# Altium schematic sheet Drive_D 1V3.SchDoc("Drive_D 1V3")
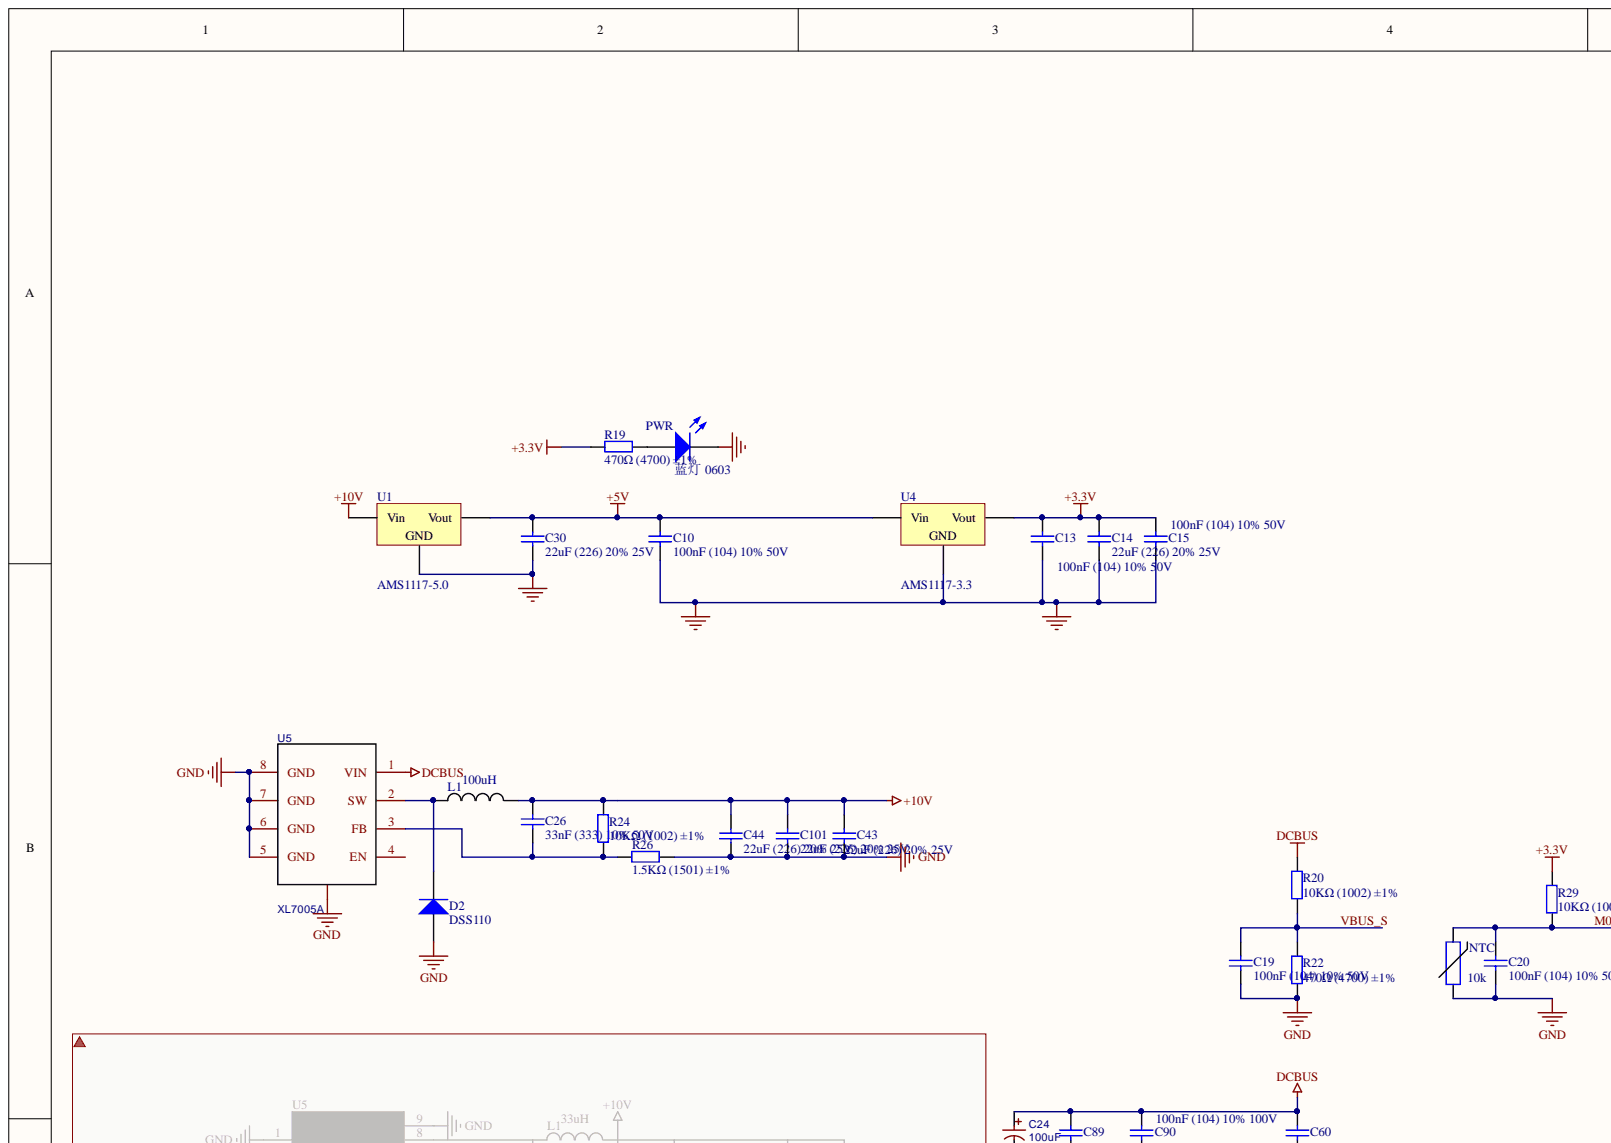
[diagram p0: Drive_D 1V3.SchDoc, top left]
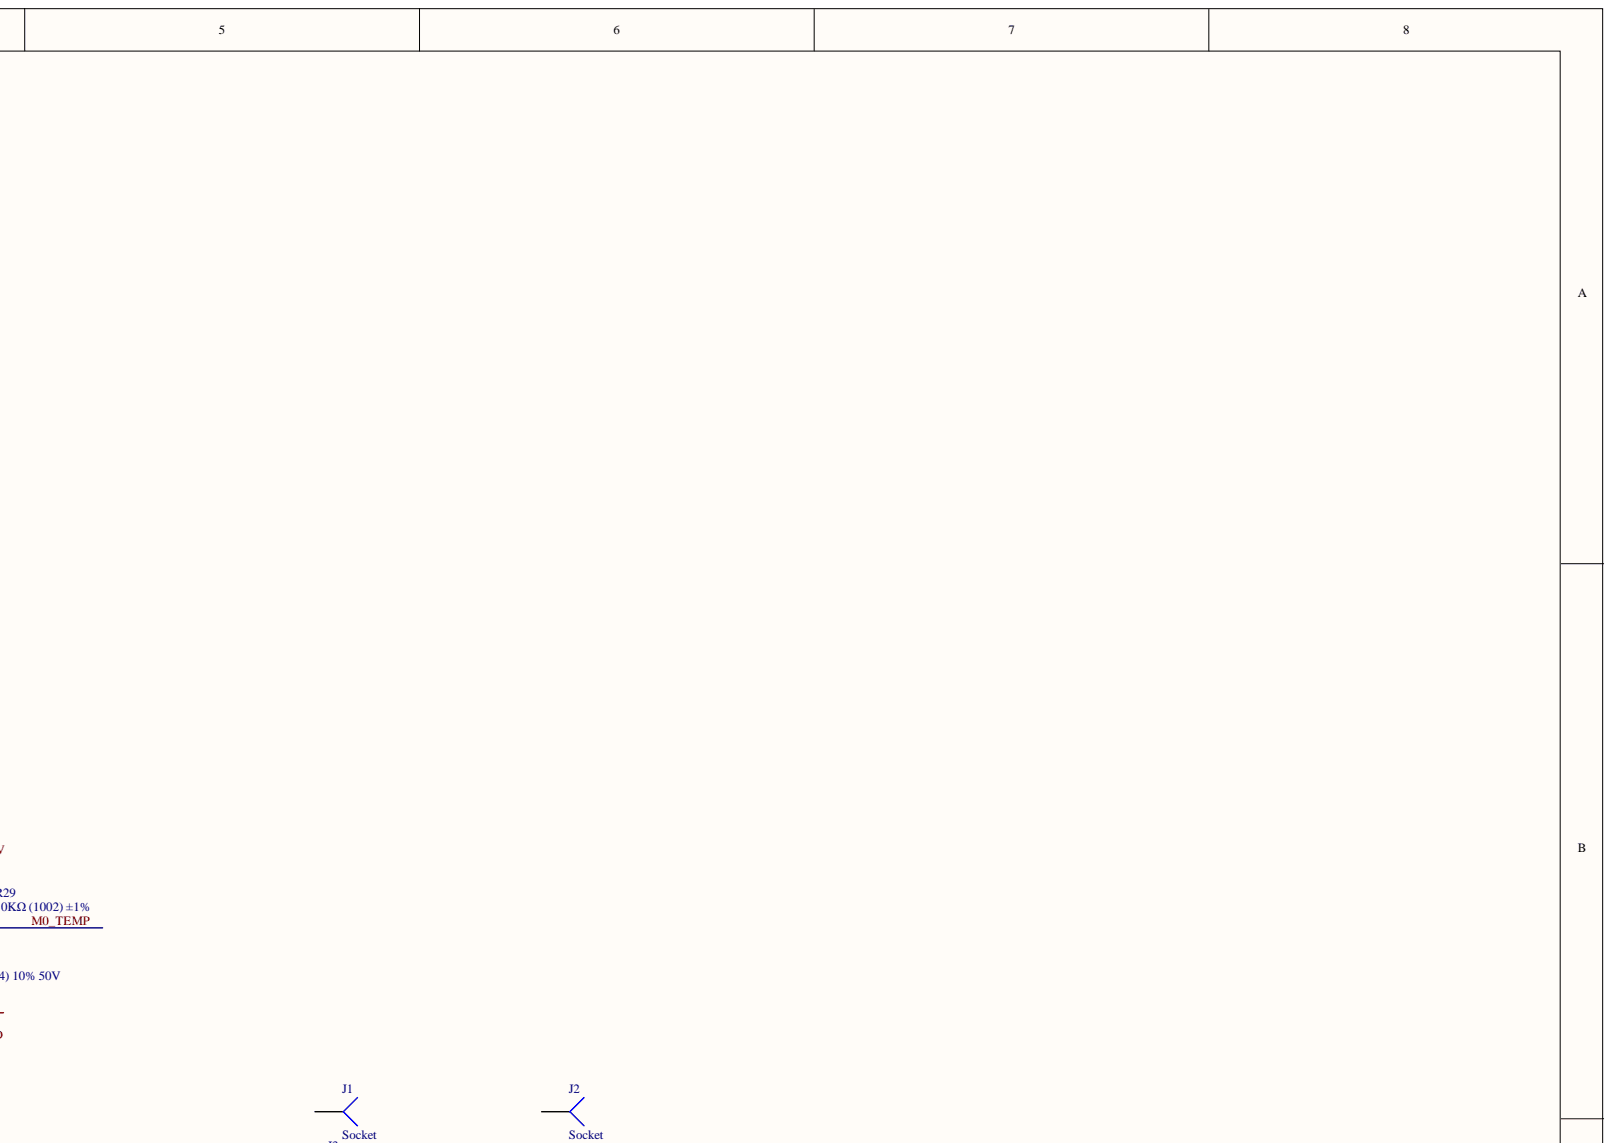
[diagram p1: Drive_D 1V3.SchDoc, top right]
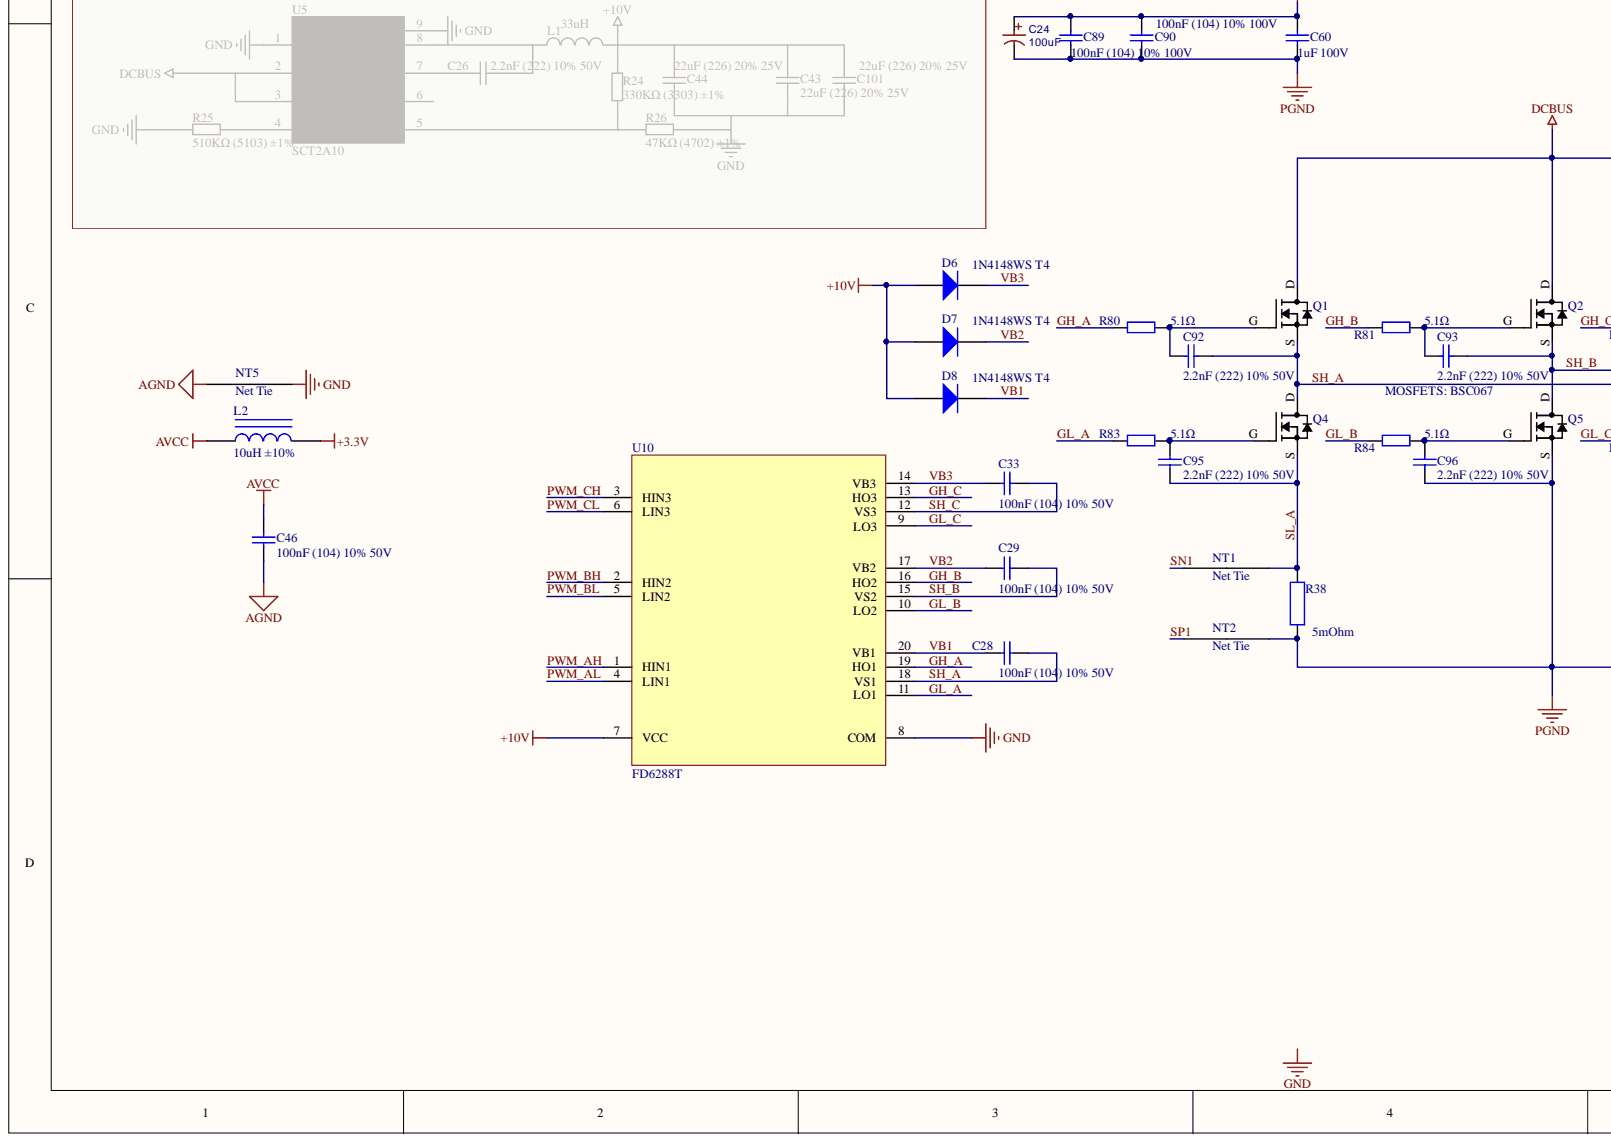
[diagram p2: Drive_D 1V3.SchDoc, bottom left]
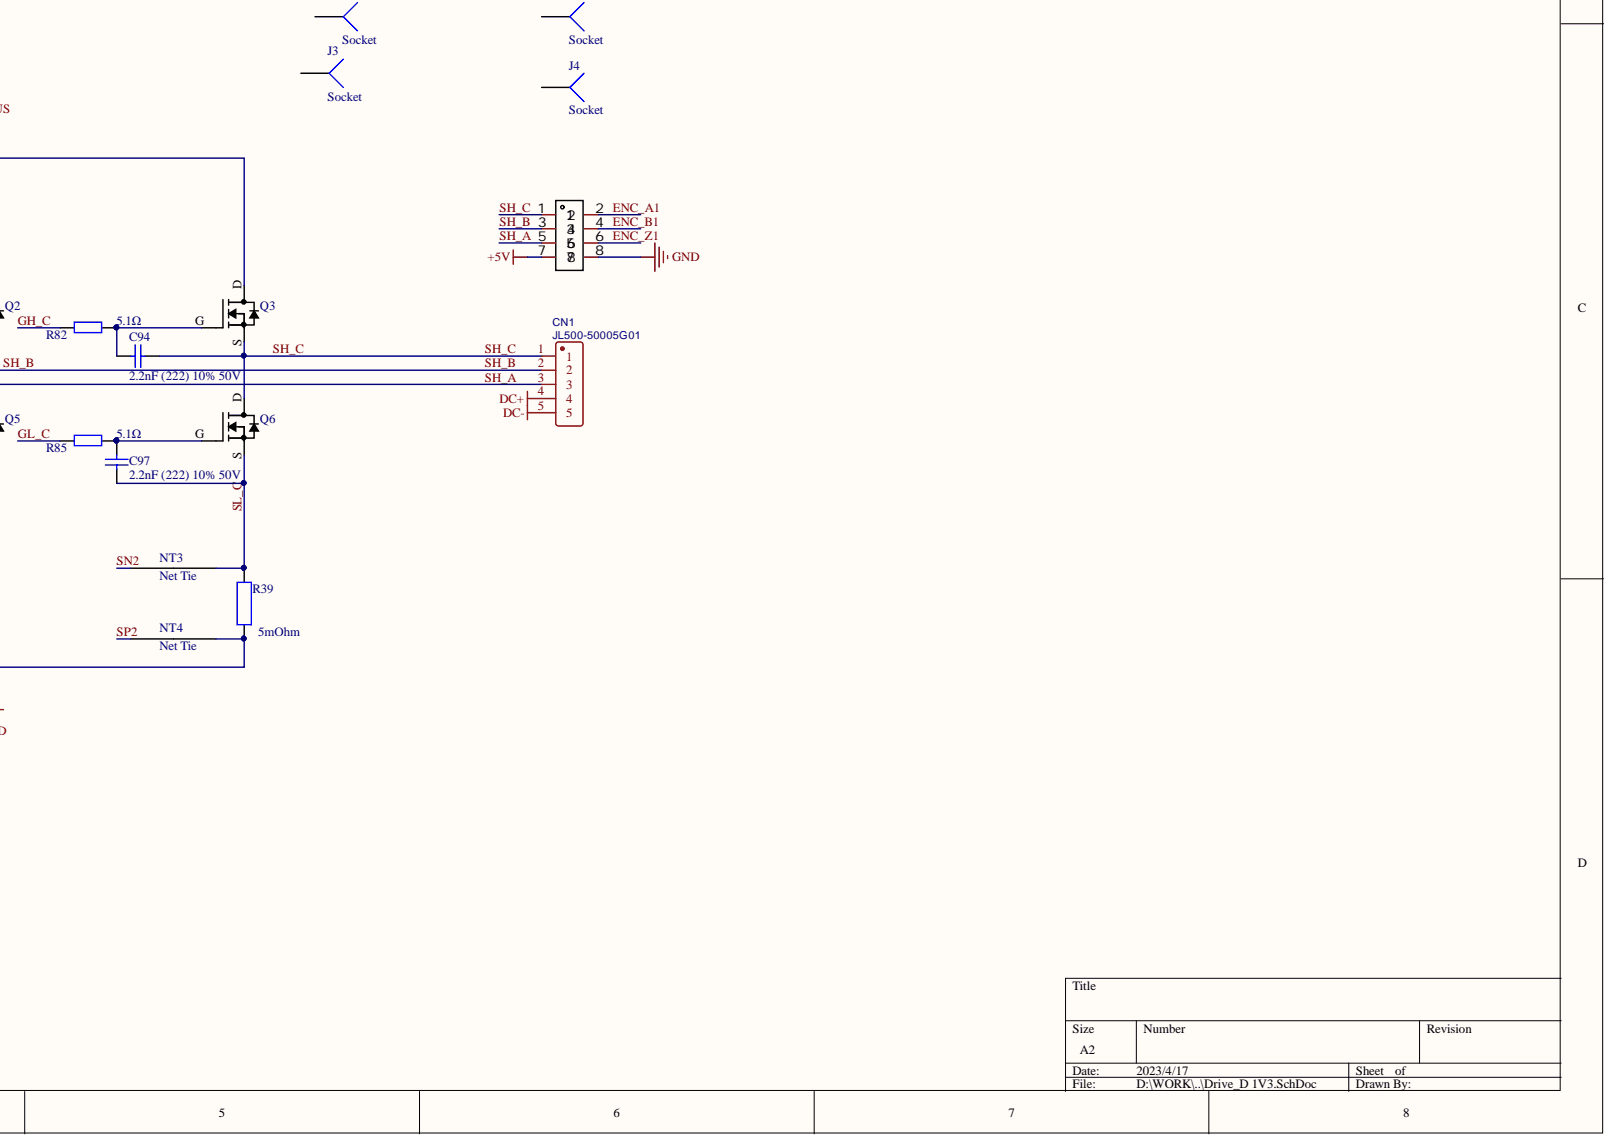
[diagram p3: Drive_D 1V3.SchDoc, bottom right]

## Parts
C10  100nF (104) 10% 50V  [0603_C]
C13  100nF (104) 10% 50V  [0603_C]
C14  22uF (226) 20% 25V  [0805_C]
C15  100nF (104) 10% 50V  [0603_C]
C19  100nF (104) 10% 50V  [0603_C]
C20  100nF (104) 10% 50V  [0603_C]
C24  100uF  [CE-5.0*10*12.5 - BK]
C26  33nF (333) 10% 50V  [0603_C]
C28  100nF (104) 10% 50V  [0603_C]
C29  100nF (104) 10% 50V  [0603_C]
C30  22uF (226) 20% 25V  [0805_C]
C33  100nF (104) 10% 50V  [0603_C]
C43  22uF (226) 20% 25V  [0805_C]
C44  22uF (226) 20% 25V  [0805_C]
C46  100nF (104) 10% 50V  [0603_C]
C60  1uF 100V  [1206_C]
C89  100nF (104) 10% 100V  [0603_C]
C90  100nF (104) 10% 100V  [0603_C]
C92  2.2nF (222) 10% 50V  [0603_C]
C93  2.2nF (222) 10% 50V  [0603_C]
C94  2.2nF (222) 10% 50V  [0603_C]
C95  2.2nF (222) 10% 50V  [0603_C]
C96  2.2nF (222) 10% 50V  [0603_C]
C97  2.2nF (222) 10% 50V  [0603_C]
C101  22uF (226) 20% 25V  [0805_C]
CN1  JL500-50005G01  [CONN-TH_JL500-50005G01]
CN4  X3025WR-2x04-46SN  [CONN-TH_X3025WR-2-04-46SN]  (value not drawn)
D2  DSS110  [SOD-123]
D6  1N4148WS T4  [SOD-323]
D7  1N4148WS T4  [SOD-323]
D8  1N4148WS T4  [SOD-323]
J1  Socket  [PCBComponent_1]
J2  Socket  [PCBComponent_1]
J3  Socket  [PCBComponent_1]
J4  Socket  [PCBComponent_1]
L1  value 100uH  [NR4020]  (hidden comment: 22uH)
L2  10uH ±10%  [0805_L]
NT1  0.25mm  [NetTie_0.25mm]  (value not drawn)
NT2  0.25mm  [NetTie_0.25mm]  (value not drawn)
NT3  0.25mm  [NetTie_0.25mm]  (value not drawn)
NT4  0.25mm  [NetTie_0.25mm]  (value not drawn)
NT5  0.25mm  [NetTie_0.25mm]  (value not drawn)
NTC  10k  [0603_R]
PWR  蓝灯 0603  Package: 0603  [0603_LED_S1]
Q1  (no value)  [PG-TDSON-8]
Q2  (no value)  [PG-TDSON-8]
Q3  (no value)  [PG-TDSON-8]
Q4  (no value)  [PG-TDSON-8]
Q5  (no value)  [PG-TDSON-8]
Q6  (no value)  [PG-TDSON-8]
R19  470Ω (4700) ±1%  [0603_R]
R20  10KΩ (1002) ±1%  [0603_R]
R22  470Ω (4700) ±1%  [0603_R]
R24  10KΩ (1002) ±1%  [0603_R]
R26  1.5KΩ (1501) ±1%  [0603_R]
R29  10KΩ (1002) ±1%  [0603_R]
R38  value 5mOhm  [R2512_M]  (hidden comment: 500uOhm)
R39  value 5mOhm  [R2512_M]  (hidden comment: 500uOhm)
R80  5.1Ω  [0603_R]
R81  5.1Ω  [0603_R]
R82  5.1Ω  [0603_R]
R83  5.1Ω  [0603_R]
R84  5.1Ω  [0603_R]
R85  5.1Ω  [0603_R]
U1  AMS1117-5.0  [SOT89_M]
U4  AMS1117-3.3  [SOT89_M]
U5  XL7005A  [LM5164]
U10  FD6288T  [TSSOP20_M]

## Nets
+3.3V: C13.2 C14.2 C15.2 L2.2 R19.1 R29.2 U4.2
+5V: C10.2 C30.2 CN4.7 U1.2 U4.3
+10V: C26.2 C43.2 C44.2 C101.2 D6.1 D7.1 D8.1 L1.2 R24.1 U1.3 U10.7(VCC)
AGND: C46.1 NT5.1
AVCC: C46.2 L2.1
DC+: CN1.4
DC-: CN1.5
DCBUS: C24.1 C60.2 C89.2 C90.2 Q1.D Q2.D Q3.D R20.2 U5.1(VIN)
GND: C10.1 C13.1 C14.1 C15.1 C19.1 C20.1 C30.1 C43.1 C44.1 C101.1 CN4.8 D2.1 NT5.2 NTC.1 PWR.K R22.1 R26.2 U1.1 U4.1 U5.5(GND) U5.6(GND) U5.7(GND) U5.8(GND) U5.9 U10.8(COM)
PGND: C24.2 C60.1 C89.1 C90.1 C96.1 NT2.2 NT4.2 Q5.S R38.1 R39.1
ENC_A1: CN4.2
ENC_B1: CN4.4
ENC_Z1: CN4.6
GH_A: R80.1 U10.19(HO1)
GH_B: R81.1 U10.16(HO2)
GH_C: R82.1 U10.13(HO3)
GL_A: R83.1 U10.11(LO1)
GL_B: R84.1 U10.10(LO2)
GL_C: R85.1 U10.9(LO3)
M0_TEMP: C20.2 NTC.2 R29.1
PWM_AH: U10.1(HIN1)
PWM_AL: U10.4(LIN1)
PWM_BH: U10.2(HIN2)
PWM_BL: U10.5(LIN2)
PWM_CH: U10.3(HIN3)
PWM_CL: U10.6(LIN3)
SH_A: C28.1 C92.1 CN1.3 CN4.5 Q1.S Q4.D U10.18(VS1)
SH_B: C29.1 C93.1 CN1.2 CN4.3 Q2.S Q5.D U10.15(VS2)
SH_C: C33.1 C94.1 CN1.1 CN4.1 Q3.S Q6.D U10.12(VS3)
SL_A: C95.1 NT1.2 Q4.S R38.2
SL_C: C97.1 NT3.2 Q6.S R39.2
SN1: NT1.1
SN2: NT3.1
SP1: NT2.1
SP2: NT4.1
VB1: C28.2 D8.2 U10.20(VB1)
VB2: C29.2 D7.2 U10.17(VB2)
VB3: C33.2 D6.2 U10.14(VB3)
VBUS_S: C19.2 R20.1 R22.2
NetC26_1: C26.1 R24.2 R26.1 U5.3(FB)
NetC92_2: C92.2 Q1.G R80.2
NetC93_2: C93.2 Q2.G R81.2
NetC94_2: C94.2 Q3.G R82.2
NetC95_2: C95.2 Q4.G R83.2
NetC96_2: C96.2 Q5.G R84.2
NetC97_2: C97.2 Q6.G R85.2
NetD2_2: D2.2 L1.1 U5.2(SW)
NetPWR_A: PWR.A R19.2

Not connected: J1 1; J2 1; J3 1; J4 1; U5 4(EN)

## Library data of the parts
C10 C13 C15 C19 C20 C28 C29 C33 C46 C89 C90 = 0603 100nF (104) 10% 50V: 贴片电容; Component Kind: Standard; ComponentLink1Description: 供应商链接; ComponentLink1URL: http://www.szlcsc.com/product/details_15331.html; Library Name: Cap.SchLib; Package: 0603; Supplier: LC
  C10 C13 C15 C19 C20 C28 C29 C33 C46: SuppliersPartNumber: C14663
  C89 C90: SuppliersPartNumber: C15725
C14 C30 C43 C44 C101 = 0805 22uF (226) 20% 25V: 贴片电容; Component Kind: Standard; ComponentLink1Description: 供应商链接; ComponentLink1URL: http://www.szlcsc.com/product/details_46786.html; Library Name: Cap.SchLib; Package: 0805; Supplier: LC; SuppliersPartNumber: C45783
C24 = 100uF: BOM_Manufacturer: CapXon; BOM_Manufacturer Part: KF101M063G125A; nameAlias: Capacitance; BOM_JLCPCB Part Class: Extended Part; BOM_Supplier: LCSC; BOM_Supplier Part: C59322; Component Kind: Standard; spicePre: C; spiceSymbolName: KF101M063G125A
C26 = 0603 10nF (103) 10% 50V: 贴片电容; Component Kind: Standard; ComponentLink1Description: 供应商链接; ComponentLink1URL: http://www.szlcsc.com/product/details_58143.html; Library Name: Miscellaneous Devices LC.IntLib; Package: 0603; Supplier: LC; SuppliersPartNumber: C57112
C60 = 1206 10uF (106) 10% 50V: 贴片电容; Component Kind: Standard; ComponentLink1Description: 供应商链接; ComponentLink1URL: http://www.szlcsc.com/product/details_14236.html; Library Name: Cap.SchLib; Package: 1206; Supplier: LC; SuppliersPartNumber: C13832
C92-C97 = 0603 2.2nF (222) 10% 50V: 贴片电容; Component Kind: Standard; ComponentLink1Description: 供应商链接; ComponentLink1URL: http://www.szlcsc.com/product/details_1956.html; Library Name: Cap.SchLib; Package: 0603; Supplier: LC; SuppliersPartNumber: C1604
CN1 = JL500-50005G01: BOM_Manufacturer: JILN(锦凌); Contributor: LCSC; BOM_JLCPCB Part Class: Extended Part; BOM_Manufacturer Part: JL500-50005G01; BOM_Supplier: LCSC; BOM_Supplier Part: C2802617; Component Kind: Standard; spicePre: U; spiceSymbolName: JL500-50005G01
CN4 = X3025WR-2x04-46SN: Manufacturer: XKB Connectivity(中国星坤); Add into BOM: yes; Current Rating (Max): 5A; Gender: 母型插口; Number of PINs Per Row: 4; Pins Structure: 2x4P; Pitch: 3mm; Symbol: X3025WR-2*04-46SN; Welding Temperature (Max): 260℃; Component Kind: Standard; Contact Material: 黄铜; Contact Plating: 锡; Convert to PCB: yes; Datasheet: https://atta.szlcsc.com/upload/public/pdf/source/20211011/E2F69B0E0CAEFC4EEA9F67EEDB1E0E84.pdf; Device: X3025WR-2x04-46SN; JLCPCB Part Class: Extended Part; LCSC Part Name: 3mm 2x4P 弯插; Manufacturer Part: X3025WR-2x04-46SN; Mounting Style: 弯插; Name: X3025WR-2x04-46SN; Number of Rows: 2; Operating Temperature Range: -25℃~+85℃; Reference Series: -; Row Spacing: 3mm; Supplier: LCSC; Supplier Footprint: 弯插,P=3mm; Supplier Part: C437083
D2 = SOD-123 B5819W  SL: 肖特基二极管; Component Kind: Standard; ComponentLink1Description: 供应商链接; ComponentLink1URL: http://www.szlcsc.com/product/details_9093.html; Library Name: Miscellaneous Devices LC.IntLib; Package: SOD-123; Supplier: LC; SuppliersPartNumber: C511869
D6-D8 = SOD-323 1N4148WS T4: 二极管; Component Kind: Standard; ComponentLink1Description: 供应商链接; ComponentLink1URL: http://www.szlcsc.com/product/details_2485.html; Library Name: Diode.SchLib; Package: SOD-323; Supplier: LC; SuppliersPartNumber: C2128
L1 = NR6028T220M: INDUCTOR 22UH 1.4A 175.5 MOHM; Component Kind: Standard; Library Name: CustomComponents.SchLib
L2 = 0805 10uH 10%: 贴片电感; Component Kind: Standard; ComponentLink1Description: 供应商链接; ComponentLink1URL: http://www.szlcsc.com/product/details_1398.html; Library Name: Miscellaneous Devices LC.IntLib; Package: 0805; Supplier: LC; SuppliersPartNumber: C1046
NT1-NT5 = NetTie_0.25mm: Component Kind: Net Tie; Library Name: CustomComponents.SchLib
NTC = NCP15XH103F03RC: THERMISTOR 10K OHM NTC 0402 SMD; Component Kind: Standard; Library Name: CustomComponents.SchLib; Value: 10k
PWR = 0603 蓝灯: 发光二极管; Component Kind: Standard; ComponentLink1Description: 供应商链接; ComponentLink1URL: http://www.szlcsc.com/product/details_73150.html; Library Name: LED.SchLib; Supplier: LC; SuppliersPartNumber: C72041
Q1-Q6 = NTMFS4935NT1G: BSC067; Manufacturer: ON Semiconductor; Current - Continuous Drain (Id) @ 25°C: 13A (Ta), 93A (Tc); Drain to Source Voltage (Vdss): 30V; Drive Voltage (Max Rds On,  Min Rds On): 4.5V, 10V; FET Type: N-Channel; Gate Charge (Qg) (Max) @ Vgs: 49.4nC @ 10V; Input Capacitance (Ciss) (Max) @ Vds: 4850pF @ 15V; Power Dissipation (Max): 930mW (Ta), 48W (Tc); Rds On (Max) @ Id, Vgs: 3.2 mOhm @ 30A, 10V; Vgs (Max): ±20V; Vgs(th) (Max) @ Id: 2.2V @ 250µA; Category: Discrete Semiconductor Products; Component Kind: Standard; ComponentLink1Description: http://www.onsemi.com/pub_link/Collateral/NTMFS4935N-D.PDF; ComponentLink1URL: http://www.onsemi.com/pub_link/Collateral/NTMFS4935N-D.PDF; FET Feature: -; Library Name: CustomComponents.SchLib; Manufacturer Part Number: NTMFS4935NT1G; Mounting Type: Surface Mount; Operating Temperature: -55°C ~ 150°C (TJ); Package / Case: 8-PowerTDFN, 5 Leads; Packaging: Cut Tape (CT); Part Status: Active; Pricing 1: 1=0.61, 10=0.541, 100=0.4145, 500=0.3277 (USD); RoHS: RoHS Compliant; Stock 1: 932; Supplier 1: Digi-Key; Supplier Device Package: 5-DFN (5x6) (8-SOFL); Supplier Part Number 1: NTMFS4935NT1GOSCT-ND; Technology: MOSFET (Metal Oxide)
R19 R22 = 0603 470Ω (4700) 1%: 贴片电阻; Component Kind: Standard; ComponentLink1Description: 供应商链接; ComponentLink1URL: http://www.szlcsc.com/product/details_23906.html; Library Name: RES.SchLib; Package: 0603; Supplier: LC; SuppliersPartNumber: C23179
R20 R24 R29 = 0603 10KΩ (1002) 1%: 贴片电阻; Component Kind: Standard; ComponentLink1Description: 供应商链接; ComponentLink1URL: http://www.szlcsc.com/product/details_26547.html; Library Name: RES.SchLib; Package: 0603; Supplier: LC; SuppliersPartNumber: C25804
R26 = 0603 1.5KΩ (1501) 1%: 贴片电阻; Component Kind: Standard; ComponentLink1Description: 供应商链接; ComponentLink1URL: http://www.szlcsc.com/product/details_23570.html; Library Name: Miscellaneous Devices LC.IntLib; Package: 0603; Supplier: LC; SuppliersPartNumber: C22843
R38 R39 = CSR2512C0R0005F: RES SMD 0.0005 OHM 1% 3W 2512; Manufacturer: Riedon; Temperature Coefficient: ±100ppm/°C; Category: Resistors; Component Kind: Standard; ComponentLink1Description: http://riedon.com/media/pdf/CSR.pdf; ComponentLink1URL: http://riedon.com/media/pdf/CSR.pdf; Composition: Metal Element; Failure Rate: -; Features: Current Sense; Height - Seated (Max): 0.057" (1.45mm); Library Name: CustomComponents.SchLib; Manufacturer Part Number: CSR2512C0R0005F; Operating Temperature: -55°C ~ 170°C; Package / Case: 2512 (6432 Metric); Packaging: Tape & Reel (TR); Part Status: Active; Power (Watts): 3W; Pricing 1: 2000=0.19817, 6000=0.1937, 10000=0.187, 50000=0.18148 (USD); Pricing 2: 1=0.69, 10=0.574, 25=0.4916, 50=0.4426, 100=0.3852, 250=0.3442, 500=0.27864, 1000=0.22536 (USD); RoHS: RoHS Compliant; Size / Dimension: 0.250" L x 0.118" W (6.35mm x 3.00mm); Stock: 2000; Supplier 1: Digi-Key; Supplier 2: Digi-Key; Supplier Device Package: 2512; Supplier Part Number 1: 696-1183-2-ND; Supplier Part Number 2: 696-1183-1-ND; Tolerance: ±1%
R80-R85 = 0603 5.1Ω (5R10) 1%: 贴片电阻; Component Kind: Standard; ComponentLink1Description: 供应商链接; ComponentLink1URL: http://www.szlcsc.com/product/details_25940.html; Library Name: RES.SchLib; Package: 0603; Supplier: LC; SuppliersPartNumber: C25197
U1 = SOT-223 AMS1117-5.0: 低压差线性稳压(LDO); Component Kind: Standard; ComponentLink1Description: 供应商链接; ComponentLink1URL: http://www.szlcsc.com/product/details_6653.html; Library Name: LDO.SchLib; Package: SOT-223; Supplier: LC; SuppliersPartNumber: C6187
U4 = SOT-223 AMS1117-3.3: 低压差线性稳压(LDO); Component Kind: Standard; ComponentLink1Description: 供应商链接; ComponentLink1URL: http://www.szlcsc.com/product/details_6652.html; Library Name: LDO.SchLib; Package: SOT-223; Supplier: LC; SuppliersPartNumber: C6186
U5 = XL7005A: BOM_Manufacturer: XLSEMI; BOM_JLCPCB Part Class: Extended Part; BOM_Manufacturer Part: XL7005A; BOM_Supplier: LCSC; BOM_Supplier Part: C50848; Component Kind: Standard; spicePre: U; spiceSymbolName: XL7005A
J1-J4 = Socket: Component Kind: Standard; Description: Socket; LatestRevisionDate: 17-Jul-2002; LatestRevisionNote: Re-released for DXP Platform.; Library Name: Miscellaneous Connectors.IntLib; Signal Integrity: Connector; Publisher: Altium Limited
U10 = FD6288T: Component Kind: Standard; Library Name: MYLIB.SchLib
